# Altium schematic sheet Sensors.SchDoc(Sensors)
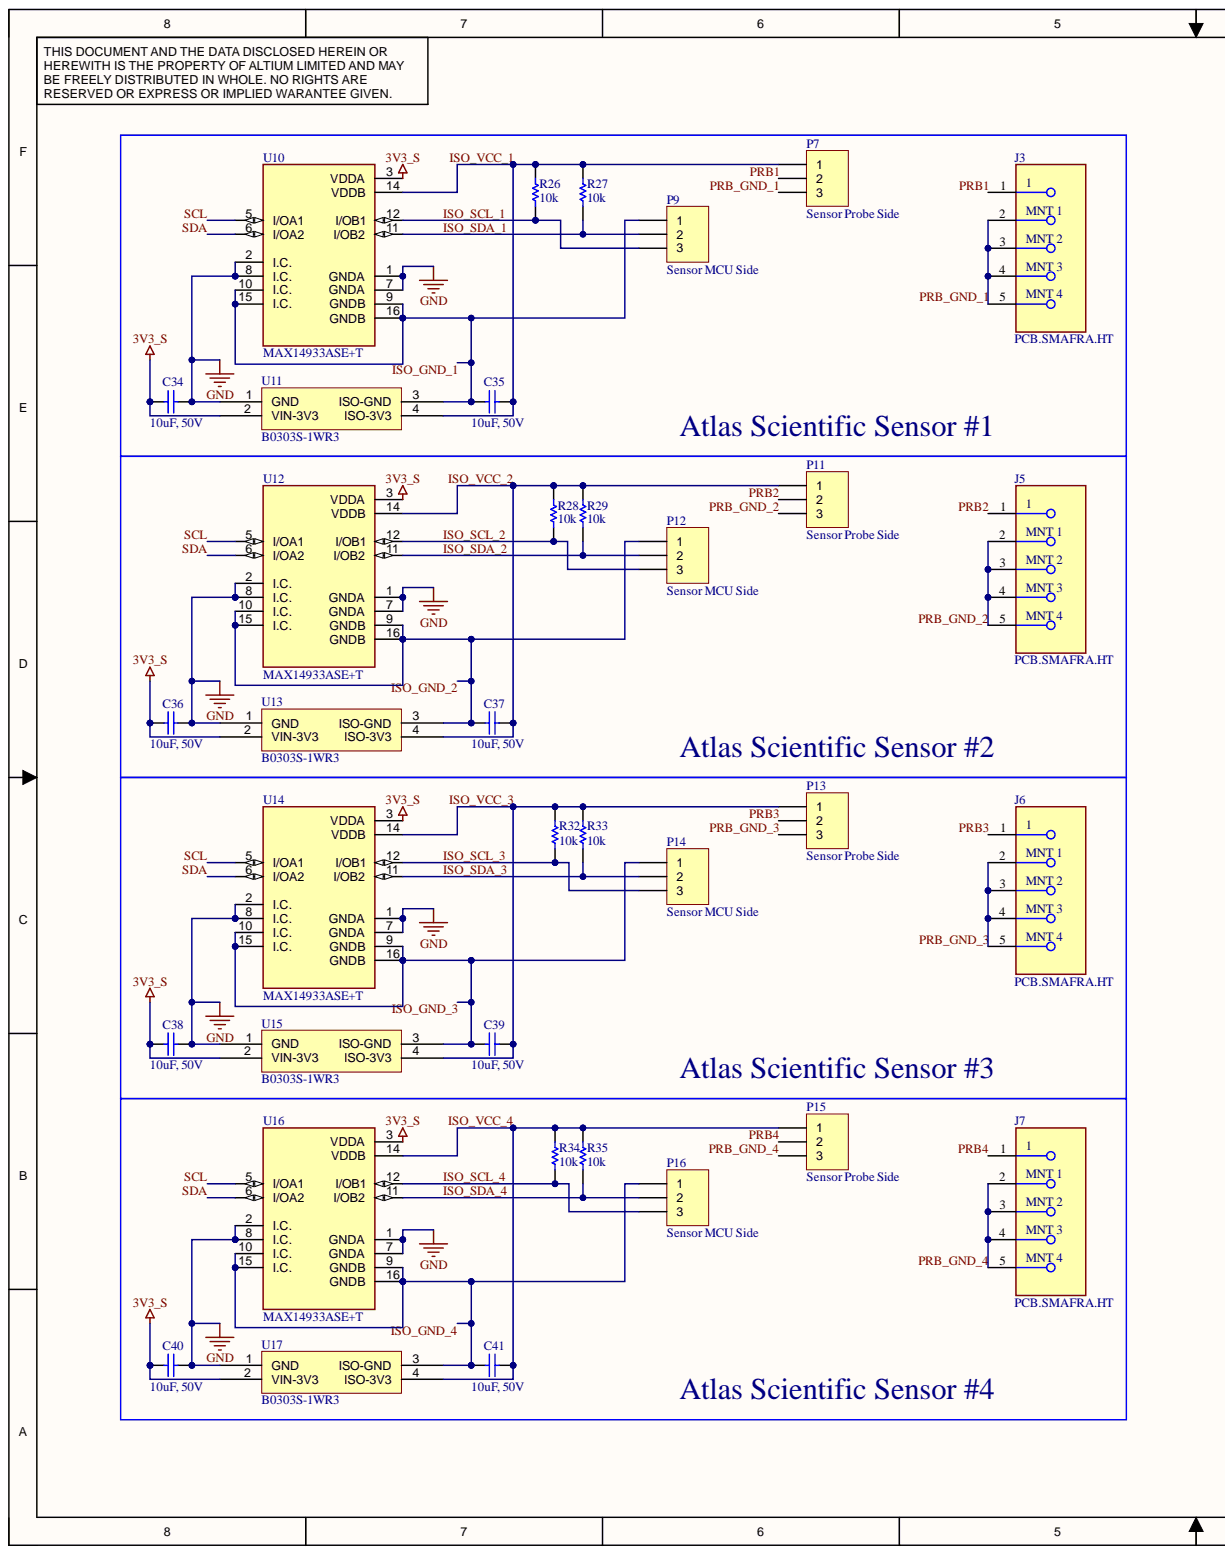
[diagram p0: Sensors.SchDoc, left]
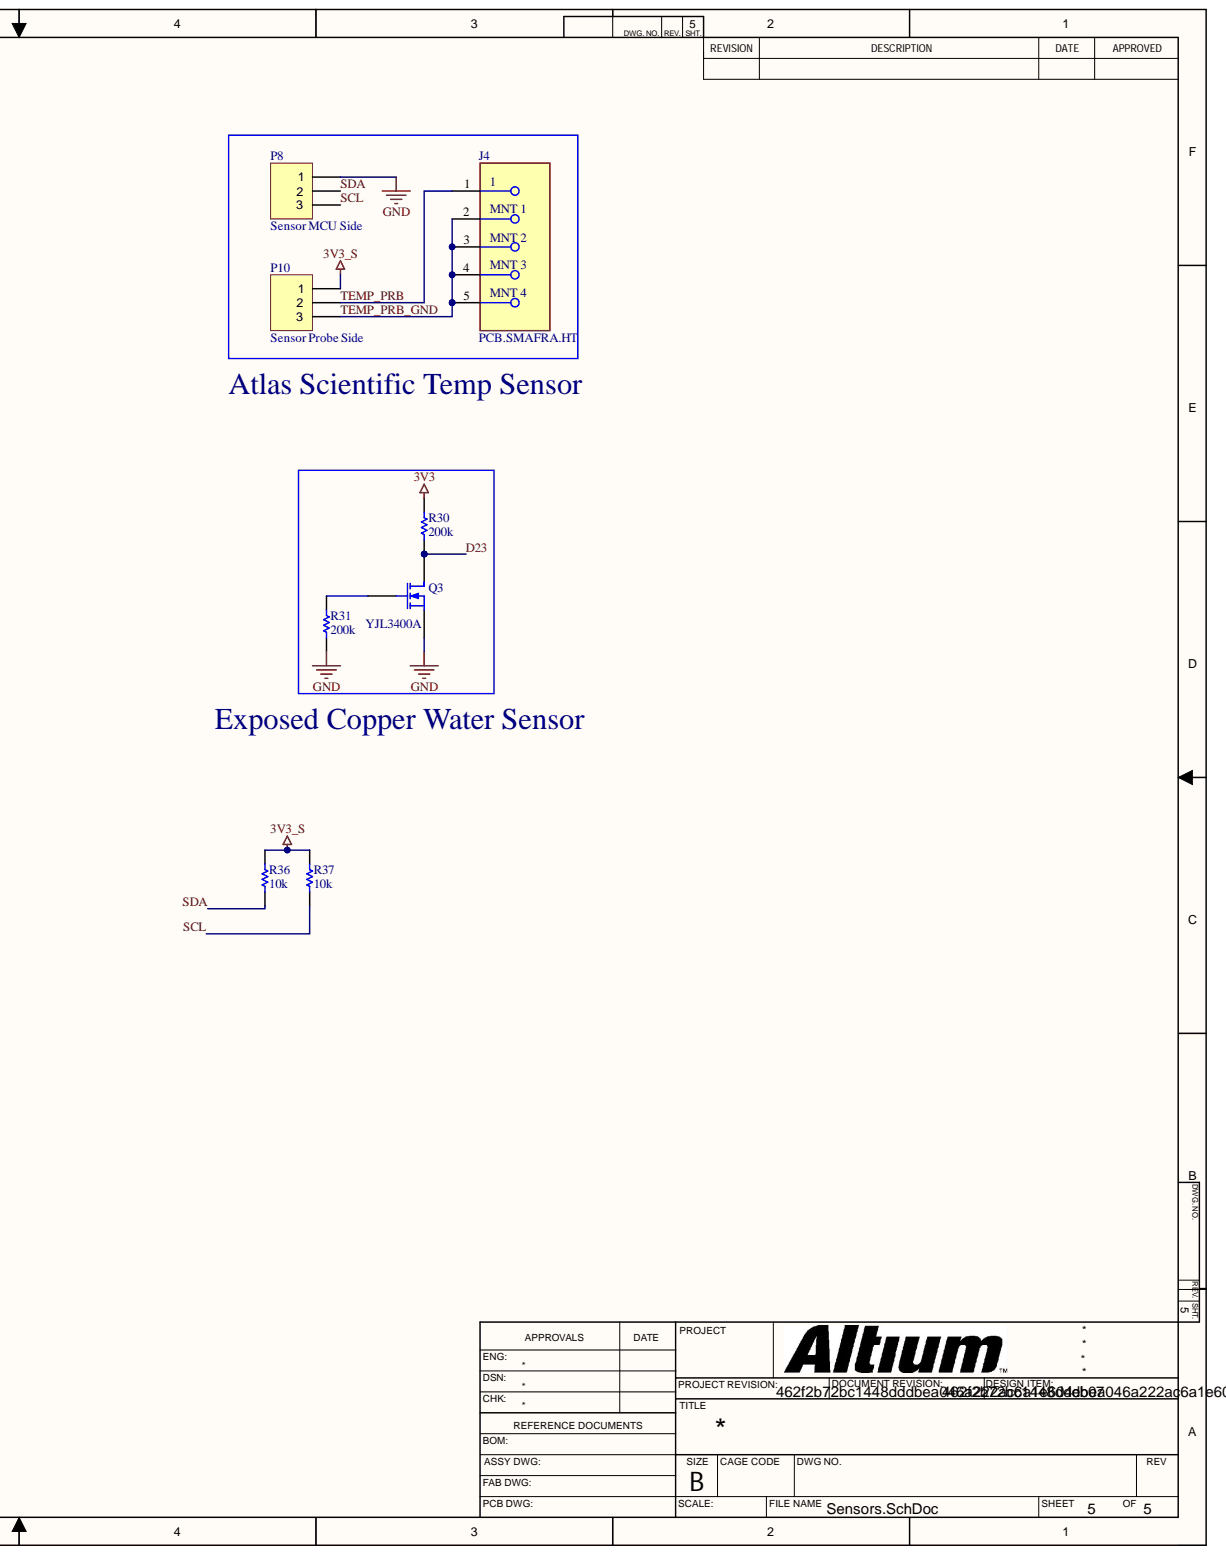
[diagram p1: Sensors.SchDoc, right]

## Parts
C34  value 10uF, 50V  [CAPC2012X145N]  (hidden comment: Capacitor)
C35  value 10uF, 50V  [CAPC2012X145N]  (hidden comment: Capacitor)
C36  value 10uF, 50V  [CAPC2012X145N]  (hidden comment: Capacitor)
C37  value 10uF, 50V  [CAPC2012X145N]  (hidden comment: Capacitor)
C38  value 10uF, 50V  [CAPC2012X145N]  (hidden comment: Capacitor)
C39  value 10uF, 50V  [CAPC2012X145N]  (hidden comment: Capacitor)
C40  value 10uF, 50V  [CAPC2012X145N]  (hidden comment: Capacitor)
C41  value 10uF, 50V  [CAPC2012X145N]  (hidden comment: Capacitor)
J3  PCB.SMAFRA.HT  [FP-PCB_SMAFRA_HT-MFG]
J4  PCB.SMAFRA.HT  [FP-PCB_SMAFRA_HT-MFG]
J5  PCB.SMAFRA.HT  [FP-PCB_SMAFRA_HT-MFG]
J6  PCB.SMAFRA.HT  [FP-PCB_SMAFRA_HT-MFG]
J7  PCB.SMAFRA.HT  [FP-PCB_SMAFRA_HT-MFG]
P7  Sensor Probe Side  [1x3 Header]
P8  Sensor MCU Side  [1x3 Header]
P9  Sensor MCU Side  [1x3 Header]
P10  Sensor Probe Side  [1x3 Header]
P11  Sensor Probe Side  [1x3 Header]
P12  Sensor MCU Side  [1x3 Header]
P13  Sensor Probe Side  [1x3 Header]
P14  Sensor MCU Side  [1x3 Header]
P15  Sensor Probe Side  [1x3 Header]
P16  Sensor MCU Side  [1x3 Header]
Q3  YJL3400A  [SOT23-3]
R26  value 10k  [ANTC1608X90N]  (hidden comment: Resistor)
R27  value 10k  [ANTC1608X90N]  (hidden comment: Resistor)
R28  value 10k  [ANTC1608X90N]  (hidden comment: Resistor)
R29  value 10k  [ANTC1608X90N]  (hidden comment: Resistor)
R30  value 200k  [ANTC1608X90N]  (hidden comment: Resistor)
R31  value 200k  [ANTC1608X90N]  (hidden comment: Resistor)
R32  value 10k  [ANTC1608X90N]  (hidden comment: Resistor)
R33  value 10k  [ANTC1608X90N]  (hidden comment: Resistor)
R34  value 10k  [ANTC1608X90N]  (hidden comment: Resistor)
R35  value 10k  [ANTC1608X90N]  (hidden comment: Resistor)
R36  value 10k  [ANTC1608X90N]  (hidden comment: Resistor)
R37  value 10k  [ANTC1608X90N]  (hidden comment: Resistor)
U10  MAX14933ASE+T  [SOIC127P600X175-16N]
U11  B0303S-1WR3  [B0303S-1WR3]
U12  MAX14933ASE+T  [SOIC127P600X175-16N]
U13  B0303S-1WR3  [B0303S-1WR3]
U14  MAX14933ASE+T  [SOIC127P600X175-16N]
U15  B0303S-1WR3  [B0303S-1WR3]
U16  MAX14933ASE+T  [SOIC127P600X175-16N]
U17  B0303S-1WR3  [B0303S-1WR3]

## Nets
3V3: R30.2
3V3_S: C34.1 C36.1 C38.1 C40.1 P10.1 R36.2 R37.2 U10.3(VDDA) U11.2(VIN-3V3) U12.3(VDDA) U13.2(VIN-3V3) U14.3(VDDA) U15.2(VIN-3V3) U16.3(VDDA) U17.2(VIN-3V3)
GND: C34.2 C36.2 C38.2 C40.2 P8.1 Q3.3 R31.1 U10.1(GNDA) U10.2(I.C.) U10.7(GNDA) U10.8(I.C.) U11.1(GND) U12.1(GNDA) U12.2(I.C.) U12.7(GNDA) U12.8(I.C.) U13.1(GND) U14.1(GNDA) U14.2(I.C.) U14.7(GNDA) U14.8(I.C.) U15.1(GND) U16.1(GNDA) U16.2(I.C.) U16.7(GNDA) U16.8(I.C.) U17.1(GND)
D23: Q3.1 R30.1
ISO_GND_1: C35.1 P9.1 U10.9(GNDB) U10.10(I.C.) U10.15(I.C.) U10.16(GNDB) U11.3(ISO-GND)
ISO_GND_2: C37.1 P12.1 U12.9(GNDB) U12.10(I.C.) U12.15(I.C.) U12.16(GNDB) U13.3(ISO-GND)
ISO_GND_3: C39.1 P14.1 U14.9(GNDB) U14.10(I.C.) U14.15(I.C.) U14.16(GNDB) U15.3(ISO-GND)
ISO_GND_4: C41.1 P16.1 U16.9(GNDB) U16.10(I.C.) U16.15(I.C.) U16.16(GNDB) U17.3(ISO-GND)
ISO_SCL_1: P9.3 R26.1 U10.12(I/OB1)
ISO_SCL_2: P12.3 R28.1 U12.12(I/OB1)
ISO_SCL_3: P14.3 R32.1 U14.12(I/OB1)
ISO_SCL_4: P16.3 R34.1 U16.12(I/OB1)
ISO_SDA_1: P9.2 R27.1 U10.11(I/OB2)
ISO_SDA_2: P12.2 R29.1 U12.11(I/OB2)
ISO_SDA_3: P14.2 R33.1 U14.11(I/OB2)
ISO_SDA_4: P16.2 R35.1 U16.11(I/OB2)
ISO_VCC_1: C35.2 P7.1 R26.2 R27.2 U10.14(VDDB) U11.4(ISO-3V3)
ISO_VCC_2: C37.2 P11.1 R28.2 R29.2 U12.14(VDDB) U13.4(ISO-3V3)
ISO_VCC_3: C39.2 P13.1 R32.2 R33.2 U14.14(VDDB) U15.4(ISO-3V3)
ISO_VCC_4: C41.2 P15.1 R34.2 R35.2 U16.14(VDDB) U17.4(ISO-3V3)
PRB1: J3.1 P7.2
PRB2: J5.1 P11.2
PRB3: J6.1 P13.2
PRB4: J7.1 P15.2
PRB_GND_1: J3.2 J3.3 J3.4 J3.5 P7.3
PRB_GND_2: J5.2 J5.3 J5.4 J5.5 P11.3
PRB_GND_3: J6.2 J6.3 J6.4 J6.5 P13.3
PRB_GND_4: J7.2 J7.3 J7.4 J7.5 P15.3
SCL: P8.3 R37.1 U10.5(I/OA1) U12.5(I/OA1) U14.5(I/OA1) U16.5(I/OA1)
SDA: P8.2 R36.1 U10.6(I/OA2) U12.6(I/OA2) U14.6(I/OA2) U16.6(I/OA2)
TEMP_PRB: J4.1 P10.2
TEMP_PRB_GND: J4.2 J4.3 J4.4 J4.5 P10.3
NetQ3_2: Q3.2 R31.2

## Library data of the parts
C34-C41 = Capacitor: SimulationGenericComponent: True; Component Kind: Standard; Description: Capacitor; Library Name: Simulation Generic Components.IntLib; Simulation: C_Capacitor_Default
J3-J7 = CMP-111093-000003-1: CONN SMA JACK R/A 50OHM PCB; Body Material: Brass; Body Plating: Gold; Dielectric Material: PTFE; Frequency: 6GHz; Impedance: 50R; Number of Ports: 1; Operating Frequency: 0 to 6 GHz; Voltage Rating: 500V; Case/Package: SMA; Center Contact Plating: Gold; Component Kind: Standard; Connector Type: SMA; Contact Material: Brass; Contact Plating: Gold; Fastening Type: Threaded; Housing Color: Gold; Library Name: Altium Content Vault; Max Frequency: 6GHz; Mount: Through Hole; Orientation: Right Angle; Package Quantity: 500; Packaging: Tray; Series: -; Termination: Solder
P7-P16 = Header 3: Header, 3-Pin; Component Kind: Standard; LatestRevisionDate: 17-Jul-2002; LatestRevisionNote: Re-released for DXP Platform.; Library Name: Miscellaneous Connectors.IntLib; Signal Integrity: Connector; Publisher: Altium Limited
Q3 = MOSFET-N: N-Channel MOSFET; Component Kind: Standard; LatestRevisionDate: 17-Jul-2002; LatestRevisionNote: Re-released for DXP Platform.; Library Name: Miscellaneous Devices.IntLib; PackageDescription: TO, Flat Index; 3 In-Line, Axial Leads; Body Dia. 4.6mm; Lead Dia. 0.5 mm (max); PackageReference: E3; PackageVersion: 1995/1996; Published: 8-Jun-2000; Simulation: NMOS; Publisher: Altium Limited
R26-R37 = Resistor: SimulationGenericComponent: True; TC1: 0; Component Kind: Standard; Description: Resistor; Library Name: Simulation Generic Components.IntLib; Simulation: R_Resistor_Default; TC2: 0
U11 U13 U15 U17 = B0303S-1WR3: 3V3 Isolator; Component Kind: Standard; Library Name: WaterNet23BotPCB.SCHLIB
U10 U12 U14 U16 = MAX14933ASE+T: Availability: Unavailable; Component Kind: Standard; Library Name: MAX14933ASE+T.SchLib; MP: MAX14933ASE+T; Package: None; Price: None
